# Altium schematic sheet Sheet1.SchDoc
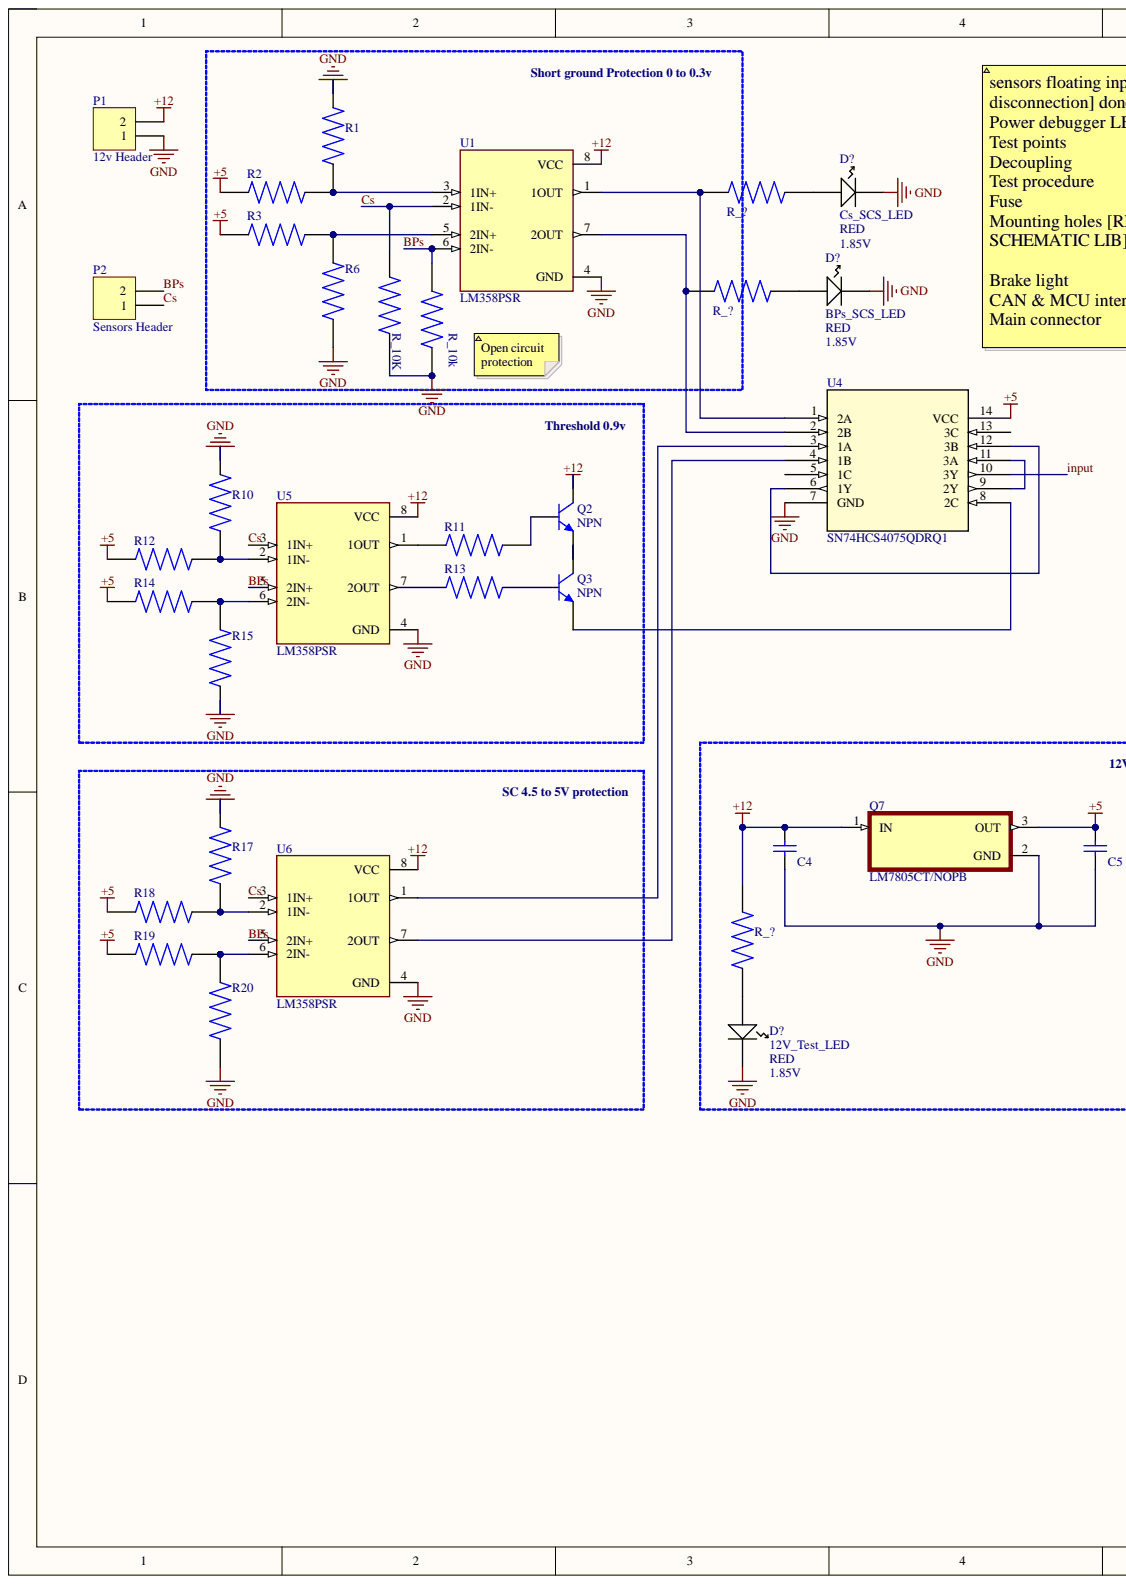
[diagram p0: Sheet1.SchDoc, left]
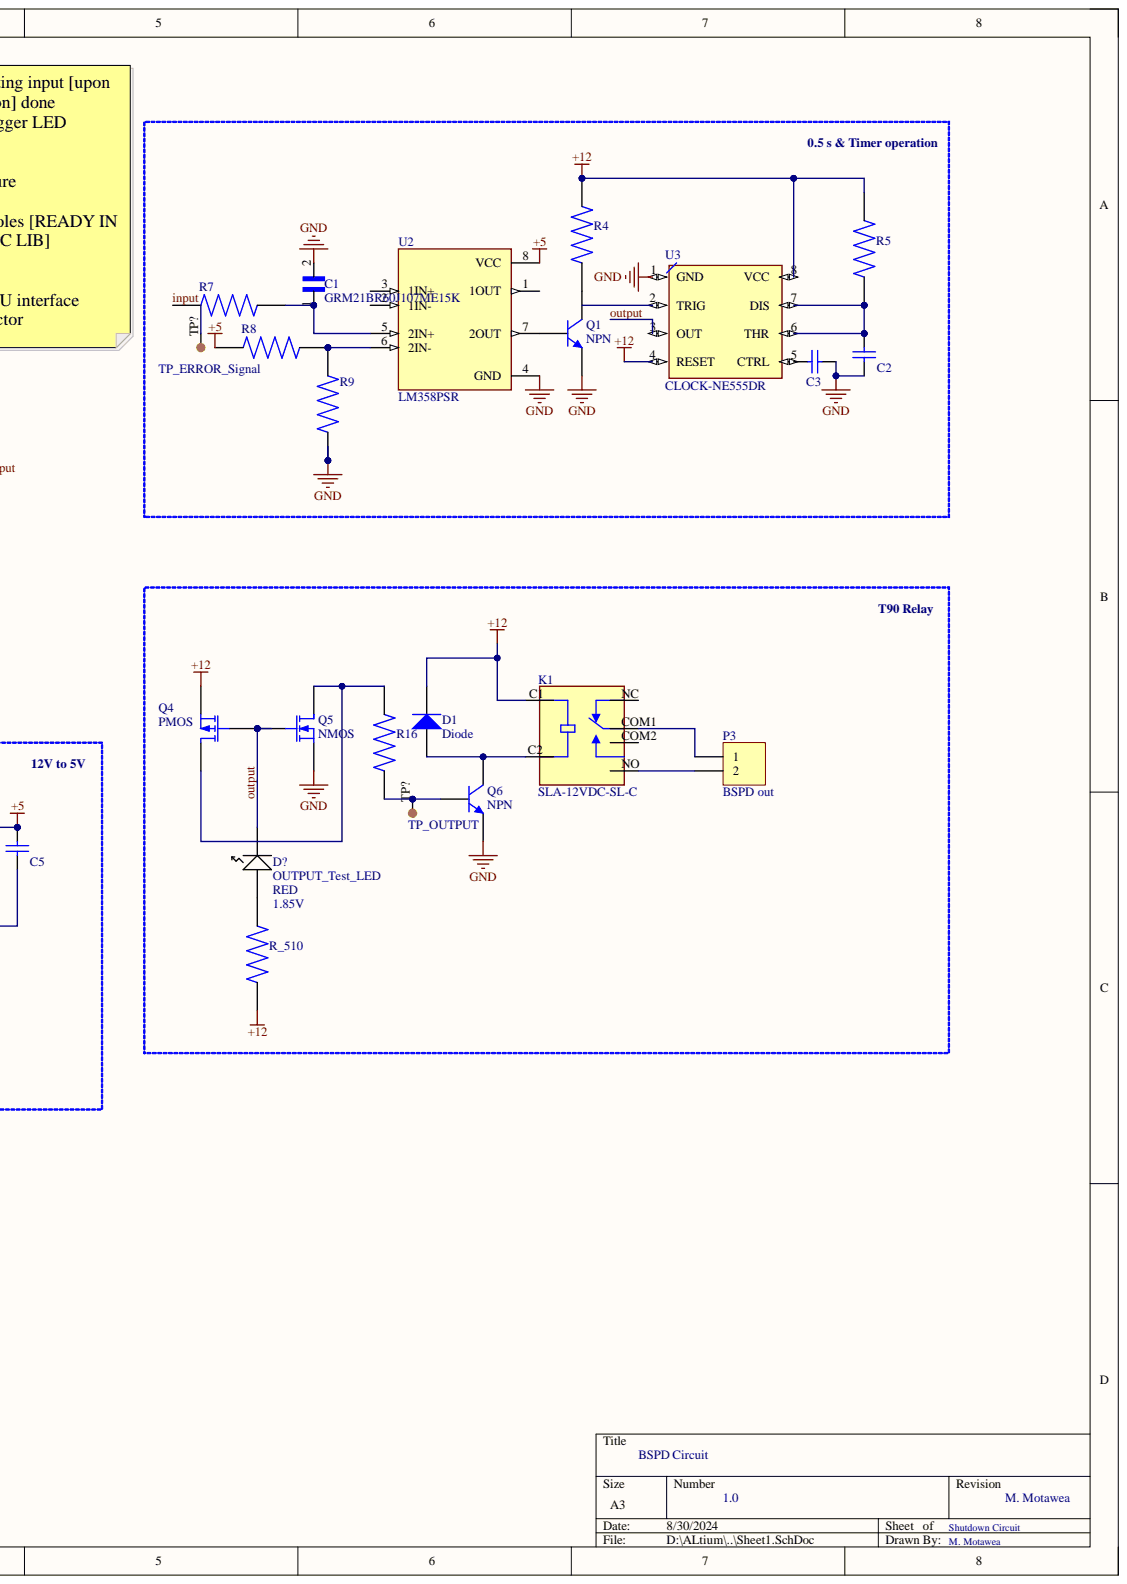
[diagram p1: Sheet1.SchDoc, right]

## Parts
C1  GRM21BR60J107ME15K  [CAPC2012X145N]
C2  10uF  [WCAP-CSGP_2220_L5.7W5H2.8]  (value not drawn)
C3  10nF  [WCAP-CSGP_1812_L4.5W3.2H1.25]  (value not drawn)
C4  220nF  [WCAP-CSGP_1210_L3.2W2.5H0.95]  (value not drawn)
C5  100nF  [WCAP-CSGP_1210_L3.2W2.5H0.95]  (value not drawn)
D1  Diode  [SMC]
D?  BPs_SCS_LED  Color: RED  Forward Voltage: 1.85V  [LED1913X12NRED]
D?  Cs_SCS_LED  Color: RED  Forward Voltage: 1.85V  [LED1913X12NRED]
D?  12V_Test_LED  Color: RED  Forward Voltage: 1.85V  [LED1913X12NRED]
D?  OUTPUT_Test_LED  Color: RED  Forward Voltage: 1.85V  [LED1913X12NRED]
K1  SLA-12VDC-SL-C  [RELAY_SLA-12VDC-SL-C]
P1  12v Header  [HDR1X2]
P2  Sensors Header  [HDR1X2]
P3  BSPD out  [HDR1X2]
Q1  NPN  [TO-226-AA]
Q2  NPN  [TO-226-AA]
Q3  NPN  [TO-226-AA]
Q4  PMOS  [E3]
Q5  NMOS  [E3]
Q6  NPN  [TO-226-AA]
Q7  LM7805CT/NOPB  [TO254P1054X470X1955-3]
R1  ERJU08J274V  [RES_ERJU08J274V]  (value not drawn)
R2  ERJU08J274V  [RES_ERJU08J274V]  (value not drawn)
R3  ERJU08J274V  [RES_ERJU08J274V]  (value not drawn)
R4  ERJU08J274V  [RES_ERJU08J274V]  (value not drawn)
R5  ERJU08J274V  [RES_ERJU08J274V]  (value not drawn)
R6  ERJU08J274V  [RES_ERJU08J274V]  (value not drawn)
R7  ERJU08J274V  [RES_ERJU08J274V]  (value not drawn)
R8  ERJU08J274V  [RES_ERJU08J274V]  (value not drawn)
R9  ERJU08J274V  [RES_ERJU08J274V]  (value not drawn)
R10  ERJU08J274V  [RES_ERJU08J274V]  (value not drawn)
R11  ERJU08J274V  [RES_ERJU08J274V]  (value not drawn)
R12  ERJU08J274V  [RES_ERJU08J274V]  (value not drawn)
R13  ERJU08J274V  [RES_ERJU08J274V]  (value not drawn)
R14  ERJU08J274V  [RES_ERJU08J274V]  (value not drawn)
R15  ERJU08J274V  [RES_ERJU08J274V]  (value not drawn)
R16  ERJU08J274V  [RES_ERJU08J274V]  (value not drawn)
R17  ERJU08J274V  [RES_ERJU08J274V]  (value not drawn)
R18  ERJU08J274V  [RES_ERJU08J274V]  (value not drawn)
R19  ERJU08J274V  [RES_ERJU08J274V]  (value not drawn)
R20  ERJU08J274V  [RES_ERJU08J274V]  (value not drawn)
R_10k  ERJU08J274V  [RES_ERJU08J274V]  (value not drawn)
R_10K  ERJU08J274V  [RES_ERJU08J274V]  (value not drawn)
R_510  ERJU08J274V  [RES_ERJU08J274V]  (value not drawn)
R_?  ERJU08J274V  [RES_ERJU08J274V]  (value not drawn)
R_?  ERJU08J274V  [RES_ERJU08J274V]  (value not drawn)
R_?  ERJU08J274V  [RES_ERJU08J274V]  (value not drawn)
TP_ERROR_Signal  TP  [Test point]
TP_OUTPUT  TP  [Test point]
U1  LM358PSR  [SOIC127P780X200-8N]
U2  LM358PSR  [SOIC127P780X200-8N]
U3  CLOCK-NE555DR  [SOIC8-1.27-5X4MM]
U4  SN74HCS4075QDRQ1  [SOIC14_D_TEX]
U5  LM358PSR  [SOIC127P780X200-8N]
U6  LM358PSR  [SOIC127P780X200-8N]

## Nets
+5: C5.2 Q7.3 R2.1 R3.1 R8.1 R12.1 R14.1 R18.1 R19.1 U2.8(VCC) U4.14(VCC)
+12: C4.2 D1.2 K1.C1 P1.2 Q2.1 Q4.3 Q7.1 R4.2 R5.2 R_510.1 R_?.1 U1.8(VCC) U3.4(RESET) U3.8(VCC) U5.8(VCC) U6.8(VCC)
GND: C1.2 C2.1 C3.2 C4.1 C5.1 D?.2 P1.1 Q1.3 Q5.3 Q6.3 Q7.2 R1.2 R6.2 R9.2 R10.2 R15.2 R17.2 R20.2 R_10K.2 R_10k.2 U1.4(GND) U2.4(GND) U3.1(GND) U4.7(GND) U5.4(GND) U6.4(GND)
BPs: P2.2 R_10k.1 U1.6(2IN-) U5.5(2IN+) U6.5(2IN+)
Cs: P2.1 R_10K.1 U1.2(1IN-) U5.3(1IN+) U6.3(1IN+)
input: R7.1 U4.10(3Y)
output: D?.2 Q4.2 Q5.2 U3.3(OUT)
NetC1_1: C1.1 R7.2 U2.5(2IN+)
NetC2_2: C2.2 R5.1 U3.6(THR) U3.7(DIS)
NetC3_1: C3.1 U3.5(CTRL)
NetD1_1: D1.1 K1.C2 Q6.1
NetD?_1: D?.1 R_510.2 R_?.2
NetK1_COM1: K1.COM1 P3.1
NetK1_NO: K1.NO P3.2
NetQ1_1: Q1.1 R4.1
NetQ1_2: Q1.2 U2.7(2OUT)
NetQ2_2: Q2.2 R11.2
NetQ2_3: Q2.3 Q3.1
NetQ3_2: Q3.2 R13.2
NetQ3_3: Q3.3 U4.8(2C)
NetQ4_1: Q4.1 Q5.1 R16.2
NetQ6_2: Q6.2 R16.1 TP_OUTPUT.TP?
NetR1_1: R1.1 R2.2 U1.3(1IN+)
NetR3_2: R3.2 R6.1 U1.5(2IN+)
NetR8_2: R8.2 R9.1 U2.6(2IN-)
NetR10_1: R10.1 R12.2 U5.2(1IN-)
NetR11_1: R11.1 U5.1(1OUT)
NetR13_1: R13.1 U5.7(2OUT)
NetR14_2: R14.2 R15.1 U5.6(2IN-)
NetR17_1: R17.1 R18.2 U6.2(1IN-)
NetR19_2: R19.2 R20.1 U6.6(2IN-)
NetR_?_1: R_?.1 U1.1(1OUT) U1.7(2OUT) U4.1(2A) U4.2(2B)
NetU4_3: U4.3(1A) U6.1(1OUT)
NetU4_4: U4.4(1B) U6.7(2OUT)
NetU4_6: U4.6(1Y) U4.12(3B)
NetU4_9: U4.9(2Y) U4.11(3A)

Not connected: K1 COM2 NC; TP_ERROR_Signal TP?; U2 1(1OUT) 2(1IN-) 3(1IN+); U3 2(TRIG); U4 5(1C) 13(3C)

## Library data of the parts
C1 = GRM21BR60J107ME15K: 0805 100 uF 6.3 V Â±20% Tolerance X7S Multilayer Ceramic Chip Capacitor; MF: Murata Electronics; Availability: In Stock; Check_prices: https://www.snapeda.com/parts/GRM21BR60J107ME15K/Murata%20Electronics%20North%20America/view-part/1845859/?ref=eda; Component Kind: Standard; Library Name: SMD 100uF cap GRM21BR60J107ME15K.IntLib; MP: GRM21BR60J107ME15K; Package: 2012 Murata; Price: None; SnapEDA_Link: https://www.snapeda.com/parts/GRM21BR60J107ME15K/Murata%20Electronics%20North%20America/view-part/1845859/?ref=snap
C2 = 885012214006: WCAP-CSGP Multilayer Ceramic Chip Capacitor, General Purpose, size 2220, X7R Class II, 10uF, 100VDC; Manufacturer: Wurth Elektronik; Capacitance Tolerance: +/-10%; Case/Size Code: 2220; Dissipation Factor: 2.5%; Insulation Resistance: 0.01GOhm; Rated Voltage (DC): 100V; Technical Reference: X7R2220106K100DFCT10000; Capacitance: 10uF; Category: Multilayer Ceramic Chip Capacitors; Ceramic Type: X7R Class II; Component Kind: Standard; ComponentLink1Description: Website Link; ComponentLink1URL: https://www.we-online.com/redexpert/article/885012214006?ad; ComponentLink2Description: Datasheet Link; ComponentLink2URL: https://www.we-online.com/redexpert/spec/885012214006?ad; Height: 2.8mm; Length: 5.7mm; Library Name: SMD cap 10nAltium_WCAP-CSGP_rev24b.IntLib; Manufacturer Part Number: 885012214006; Match Code: WCAP-CSGP; Mount: Surface Mount; Operating Temperature Max: 125°C; Operating Temperature Min: -55°C; Packaging: Tape and Reel; Simulation: 2220_885012214006_10uF; Width: 5mm
C3 = 885012210019: WCAP-CSGP Multilayer Ceramic Chip Capacitor, General Purpose, size 1812, X7R, 10nF, 50VDC; Manufacturer: Wurth Elektronik; Capacitance Tolerance: +/-10%; Case/Size Code: 1812; Dissipation Factor: 2.5%; Insulation Resistance: 10GOhm; Rated Voltage (DC): 50V; Technical Reference: X7R1812103K050DFCT10000; Capacitance: 10nF; Category: Multilayer Ceramic Chip Capacitors; Ceramic Type: X7R; Component Kind: Standard; ComponentLink1Description: Website Link; ComponentLink1URL: https://www.we-online.com/redexpert/article/885012210019?ad; ComponentLink2Description: Datasheet Link; ComponentLink2URL: https://www.we-online.com/redexpert/spec/885012210019?ad; Height: 1.25mm; Length: 4.5mm; Library Name: SMD cap 10nAltium_WCAP-CSGP_rev24b.IntLib; Manufacturer Part Number: 885012210019; Match Code: WCAP-CSGP; Mount: Surface Mount; Operating Temperature Max: 125°C; Operating Temperature Min: -55°C; Packaging: Tape and Reel; Simulation: 1812_885012210019_10nF; Width: 3.2mm
C4 = 885012209020: WCAP-CSGP Multilayer Ceramic Chip Capacitor, General Purpose, size 1210, X7R, 220nF, 25VDC; Manufacturer: Wurth Elektronik; Capacitance Tolerance: +/-10%; Case/Size Code: 1210; Dissipation Factor: 3.5%; Insulation Resistance: 2.3GOhm; Rated Voltage (DC): 25V; Technical Reference: X7R1210224K025DFCT10000; Capacitance: 220nF; Category: Multilayer Ceramic Chip Capacitors; Ceramic Type: X7R; Component Kind: Standard; ComponentLink1Description: Website Link; ComponentLink1URL: https://www.we-online.com/redexpert/article/885012209020?ad; ComponentLink2Description: Datasheet Link; ComponentLink2URL: https://www.we-online.com/redexpert/spec/885012209020?ad; Height: 0.95mm; Length: 3.2mm; Library Name: SMD cap 10nAltium_WCAP-CSGP_rev24b.IntLib; Manufacturer Part Number: 885012209020; Match Code: WCAP-CSGP; Mount: Surface Mount; Operating Temperature Max: 125°C; Operating Temperature Min: -55°C; Packaging: Tape and Reel; Simulation: 1210_885012209020_220nF; Width: 2.5mm
C5 = 885012209019: WCAP-CSGP Multilayer Ceramic Chip Capacitor, General Purpose, size 1210, X7R, 100nF, 25VDC; Manufacturer: Wurth Elektronik; Capacitance Tolerance: +/-10%; Case/Size Code: 1210; Dissipation Factor: 3.5%; Insulation Resistance: 5GOhm; Rated Voltage (DC): 25V; Technical Reference: X7R1210104K025DFCT10000; Capacitance: 100nF; Category: Multilayer Ceramic Chip Capacitors; Ceramic Type: X7R; Component Kind: Standard; ComponentLink1Description: Website Link; ComponentLink1URL: https://www.we-online.com/redexpert/article/885012209019?ad; ComponentLink2Description: Datasheet Link; ComponentLink2URL: https://www.we-online.com/redexpert/spec/885012209019?ad; Height: 0.95mm; Length: 3.2mm; Library Name: SMD cap 10nAltium_WCAP-CSGP_rev24b.IntLib; Manufacturer Part Number: 885012209019; Match Code: WCAP-CSGP; Mount: Surface Mount; Operating Temperature Max: 125°C; Operating Temperature Min: -55°C; Packaging: Tape and Reel; Simulation: 1210_885012209019_100nF; Width: 2.5mm
D1 = Diode: Default Diode; Code_JEDEC: DO-214-AB; Component Kind: Standard; LatestRevisionDate: 17-Jul-2002; LatestRevisionNote: Re-released for DXP Platform.; Library Name: Miscellaneous+Devices.IntLib; PackageDescription: DO-214-AB/SMC; 2 C-Bend Leads; Body 7.9 x 5.9 mm, inc. leads (LxW); PackageReference: SMC; PackageVersion: Sep-1996; Published: 8-Jun-2000; Signal Integrity: Diode; Simulation: DIODE; Publisher: Altium Limited
D? D? D? D? = Kingbright Red LED, 100 mcd 120 ° 0805: Manufacturer Part No.: KP-2012SRC-PRV; Component Kind: Standard; Datasheet URL: http://za.rs-online.com/web/p/visible-leds/4663813/; Date Created: 18 February 2015; Description: Kingbright Red LED, 100 mcd 120 ° 0805; Library Name: KingBright LEDs.IntLib; Manufacturer: KingBright; RS Stock No.: 466-3813; Creator: Ritesh Kanjee
K1 = SLA-12VDC-SL-C: SUBMINATURE HIGH POWER RELAY; MF: Songle Relay; Availability: Not in stock; Check_prices: https://www.snapeda.com/parts/SLA-12VDC-SL-C/Songle+Relay/view-part/?ref=eda; Component Kind: Standard; Library Name: SLA-12VDC-SL-C.IntLib; MP: SLA-12VDC-SL-C; Package: None; Price: None; SnapEDA_Link: https://www.snapeda.com/parts/SLA-12VDC-SL-C/Songle+Relay/view-part/?ref=snap
P1-P3 = Header 2: Header, 2-Pin; Component Kind: Standard; LatestRevisionDate: 17-Jul-2002; LatestRevisionNote: Re-released for DXP Platform.; Library Name: Miscellaneous+Connectors.IntLib; Signal Integrity: Connector; Publisher: Altium Limited
Q1-Q3 Q6 = NPN: NPN Bipolar Transistor; Code_JEDEC: TO-226-AA; Component Kind: Standard; LatestRevisionDate: 17-Jul-2002; LatestRevisionNote: Re-released for DXP Platform.; Library Name: Miscellaneous+Devices.IntLib; PackageDescription: TO, Flat Index; 3 In-Line, Axial Leads; Body Dia. 4.8mm; Leads 0.55 x 0.51 mm (max); PackageReference: TO-226-AA; Published: 8-Jun-2000; Signal Integrity: NPN; Simulation: NPN; Publisher: Altium Limited
Q4 = MOSFET-P: P-Channel MOSFET; Component Kind: Standard; LatestRevisionDate: 17-Jul-2002; LatestRevisionNote: Re-released for DXP Platform.; Library Name: Miscellaneous+Devices.IntLib; PackageDescription: TO, Flat Index; 3 In-Line, Axial Leads; Body Dia. 4.6mm; Lead Dia. 0.5 mm (max); PackageReference: E3; PackageVersion: 1995/1996; Published: 8-Jun-2000; Simulation: PMOS; Publisher: Altium Limited
Q5 = MOSFET-N: N-Channel MOSFET; Component Kind: Standard; LatestRevisionDate: 17-Jul-2002; LatestRevisionNote: Re-released for DXP Platform.; Library Name: Miscellaneous+Devices.IntLib; PackageDescription: TO, Flat Index; 3 In-Line, Axial Leads; Body Dia. 4.6mm; Lead Dia. 0.5 mm (max); PackageReference: E3; PackageVersion: 1995/1996; Published: 8-Jun-2000; Simulation: NMOS; Publisher: Altium Limited
R1-R20 R_10k R_10K R_510 R_? R_? R_? = ERJU08J274V: 1206 anti-sulfurated resistor 1206 Anti-Sulfurated; MF: Panasonic; MP: ERJ-U08J274V; Check_prices: https://www.snapeda.com/parts/ERJ-U08J274V/Panasonic/view-part/?ref=eda; Component Kind: Standard; Library Name: 1206 resistor ERJU08J274V.IntLib; Package: 3216-2 Panasonic; SnapEDA_Link: https://www.snapeda.com/parts/ERJ-U08J274V/Panasonic/view-part/?ref=snap
TP_ERROR_Signal TP_OUTPUT = Test point: Component Kind: Standard; Library Name: TP.IntLib; Value: TP
U1 U2 U5 U6 = LM358PSR: General Purpose Amplifier 2 Circuit 8-SO; MF: Texas Instruments; Availability: Unavailable; Component Kind: Standard; Library Name: LM358PSR.IntLib; MP: LM358PSR; Package: SO-8 Texas Instruments; Price: None; SnapEDA_Link: https://www.snapeda.com/parts/LM358PSR/Texas%20Instruments/view-part/501080/?ref=snap
U3 = CLOCK-NE555DR(SOIC8): Single Precision Timer; MF: Texas Instruments; Check_prices: https://www.snapeda.com/parts/NE555DR/Texas+Instruments/view-part/?ref=eda; Component Kind: Standard; Library Name: CLOCK-NE555DR_SOIC8_.IntLib; MP: NE555DR; Package: SOIC-8 Texas Instruments; SnapEDA_Link: https://www.snapeda.com/parts/NE555DR/Texas+Instruments/view-part/?ref=snap
U4 = SN74HCS4075QDRQ1: No Description Available; Mfr_Name: Texas Instruments; Component Kind: Standard; Library Name: SN74HCS4075QDRQ1.IntLib; RefDes: RefDes; Type: Logic
Q7 = LM7805CT/NOPB: Availability: Unavailable; Component Kind: Standard; Library Name: LM7805CT_NOPB.IntLib; MP: LM7805CT/NOPB; Package: None; Price: None
